# Altium schematic sheet Sheet1.SchDoc(Sheet1)
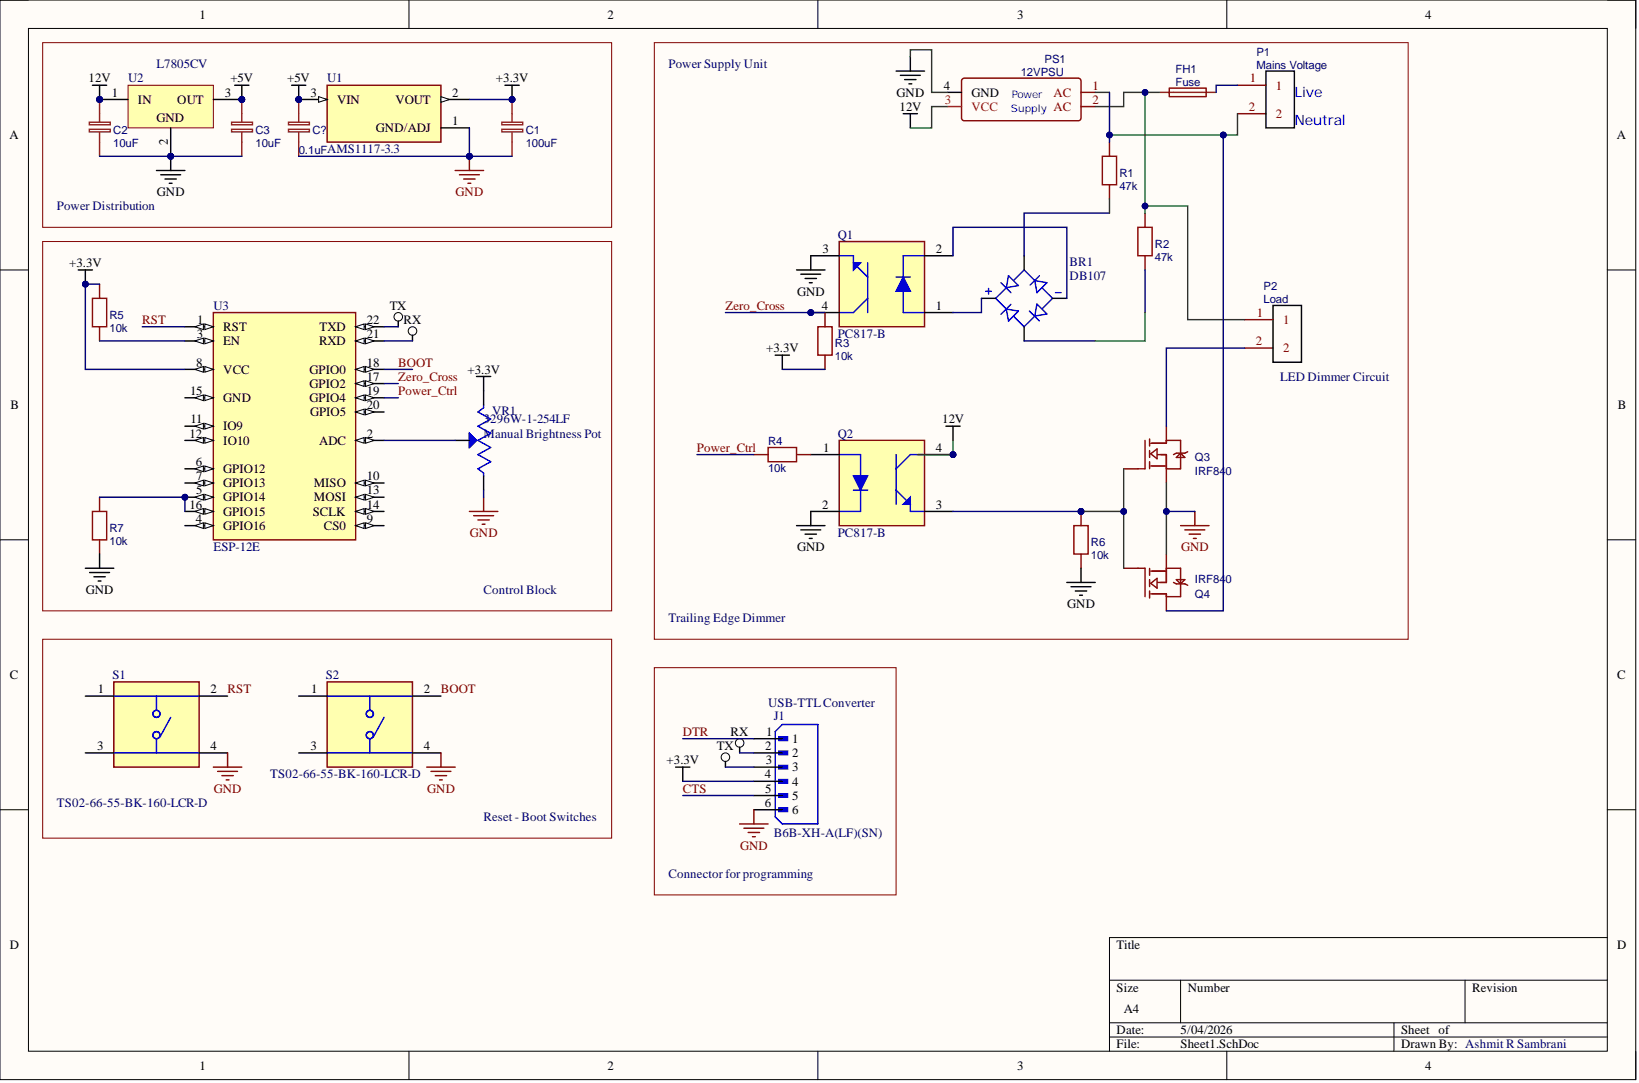
[diagram p0: Sheet1.SchDoc]

## Parts
BR1  DB107
C1  100uF
C2  10uF
C3  10uF
C?  0.1uF
FH1  Fuse
J1  B6B-XH-A(LF)(SN)
P1  Mains Voltage
P2  Load
PS1  12VPSU
Q1  PC817-B
Q2  PC817-B
Q3  IRF840
Q4  IRF840
R1  47k
R2  47k
R3  10k
R4  10k
R5  10k
R6  10k
R7  10k
S1  TS02-66-55-BK-160-LCR-D
S2  TS02-66-55-BK-160-LCR-D
U1  AMS1117-3.3
U2  L7805CV
U3  ESP-12E
VR1  3296W-1-254LF

## Nets
12V: C2.1 PS1.3(VCC) Q2.4 U2.1
+3.3V: C1.1 J1.4 R3.1 R5.2 U1.2 U3.8(VCC) VR1.3
+5V: C3.1 C?.1 U1.3 U2.3
GND: C1.2 C2.2 C3.2 C?.2 J1.6 PS1.4(GND) Q1.3 Q2.2 Q3.3 Q4.3 R6.1 R7.1 S1.4 S2.4 U1.1 U2.2 VR1.1
RX: J1.2 U3.21(RXD)
TX: J1.3 U3.22(TXD)
BOOT: S2.2 U3.18(GPIO0)
CTS: J1.5
DTR: J1.1
Power_Ctrl: R4.1 U3.19(GPIO4)
RST: S1.2 U3.1(RST)
Zero_Cross: Q1.4 R3.2 U3.17(GPIO2)
NetBR1_1: BR1.1 Q1.1
NetBR1_2: BR1.2 Q1.2
NetBR1_3: BR1.3 R1.1
NetBR1_4: BR1.4 R2.1
NetFH1_1: FH1.1 P2.1 PS1.2(AC) R2.2
NetFH1_2: FH1.2 P1.1
NetP1_2: P1.2 PS1.1(AC) Q4.4 R1.2
NetP2_2: P2.2 Q3.4
NetQ2_1: Q2.1 R4.2
NetQ2_3: Q2.3 Q3.1 Q4.1 R6.2
NetR5_1: R5.1 U3.3(EN)
NetR7_2: R7.2 U3.5(GPIO14) U3.16(GPIO15)
NetU3_2: U3.2(ADC) VR1.2

Not connected: S1 1 3; S2 1 3; U3 4(GPIO16) 6(GPIO12) 7(GPIO13) 9(CS0) 10(MISO) 11(IO9) 12(IO10) 13(MOSI) 14(SCLK) 15(GND) 20(GPIO5)

## Library data of the parts
BR1 = CMP-033-000001-1: Bridge Rectifier Single Phase Standard 1 kV Through Hole DB-1; MF: Micro Commercial Components; Check_prices: https://www.snapeda.com/parts/DB107/Micro+Commercial+Components/view-part/?ref=eda; Component Kind: Standard; Component Type: Standard; Design Item ID: DB107; MP: DB107; Package: EDIP-4 MCC; Pin Count: 4; SnapEDA_Link: https://www.snapeda.com/parts/DB107/Micro+Commercial+Components/view-part/?ref=snap; Source: DB107.SchLib; Library Name: Ashmita Das
C1-C3 C? = 2.2uF: nameAlias: Value(F); Component Kind: Standard; Manufacturer Part: ?; spicePre: C; spiceSymbolName: Cap_1206_EU
FH1 = Fuse: Manufacturer: Littelfuse; Manufacturer Part: 64600001003; Contributor: LCSC; Component Kind: Standard; spicePre: F; Supplier: LCSC; Supplier Part: C206887
J1 = CMP-398-000515-1: Connector Header Through Hole 6 position 0.098 (2.50mm); MF: JST Sales; Check_prices: https://www.snapeda.com/parts/B6B-XH-A(LF)(SN)/JST+Sales+America+Inc./view-part/?ref=eda; Component Kind: Standard; Component Type: Standard; Design Item ID: B6B-XH-A(LF)(SN); Library Name: CSD Robocon Workspace; MP: B6B-XH-A(LF)(SN); Package: None; Pin Count: 6; SnapEDA_Link: https://www.snapeda.com/parts/B6B-XH-A(LF)(SN)/JST+Sales+America+Inc./view-part/?ref=snap; Source: B6B-XH-A(LF)(SN).SchLib
P1 = Mains Voltage: Manufacturer Part: Screw terminal 2p; Contributor: georges_circuits; Component Kind: Standard; spicePre: P
P2 = Load: Manufacturer Part: Screw terminal 2p; Contributor: georges_circuits; Component Kind: Standard; spicePre: P
PS1 = 12VPSU: Manufacturer Part: Hi-Link/Tenstar; Contributor: doMOD; Component Kind: Standard; spicePre: P
Q1 Q2 = CMP-035-000000-1: Phototransistors 4-DIP (0.300, 7.62mm); MF: American Bright; Check_prices: https://www.snapeda.com/parts/PC817-B/American+Bright/view-part/?ref=eda; Component Kind: Standard; Component Type: Standard; Design Item ID: PC817-B; MP: PC817-B; Package: DIP-4 American Bright; Pin Count: 4; SnapEDA_Link: https://www.snapeda.com/parts/PC817-B/American+Bright/view-part/?ref=snap; Source: PC817-B.SchLib; Library Name: Ashmita Das
Q3 Q4 = IRF840: Manufacturer: VISHAY; Manufacturer Part: IRF840STRPBF; Contributor: LCSC; SMT Type: Extend; Component Kind: Standard; LCSC Assembly: Yes; spicePre: Q; Supplier: LCSC; Supplier Part: C2646
R1-R5 R7 = 47k: nameAlias: Value(Ω); Component Kind: Standard; Manufacturer Part: ?; spicePre: R; spiceSymbolName: Res_1206(1/4W)_EU
R6 = 10k: nameAlias: Value(Ω); Component Kind: Standard; Manufacturer Part: ?; spicePre: R; spiceSymbolName: Res_1206(1/4W)_EU
S1 S2 = CMP-342-000000-2: 5mm Tactilte Push Button; Availability: Unavailable; Component Kind: Standard; Component Type: Standard; Design Item ID: TS02-66-55-BK-160-LCR-D; Library Name: CSD Robocon Workspace; MP: TS02-66-55-BK-160-LCR-D; Package: None; Pin Count: 4; Price: None; Source: TS02-66-55-BK-160-LCR-D.SchLib
U1 = CMP-014-00002-1: Sot223/Pkg 1-Amp 3.3-Volt Low Drop Out Voltage Regulatator; MF: Advanced Monolithic Systems; Case/Package: SOT-223 Seeed Technology; Check_prices: https://www.snapeda.com/parts/AMS1117-3.3/Advanced+Monolithic+Systems/view-part/?ref=eda; Component Kind: Standard; Component Type: Standard; Design Item ID: AMS1117-3.3; MP: AMS1117-3.3; Pins: 3; SnapEDA_Link: https://www.snapeda.com/parts/AMS1117-3.3/Advanced+Monolithic+Systems/view-part/?ref=snap; Source: AMS1117-3.3.SchLib; Library Name: Ashmita Das
U2 = CMP-019-00018-3: Positive Voltage Regulator IC, 1.5 A, 5 V, 0 to 125 degC, 3-Pin TO-220, RoHS, Tube; Manufacturer: STMicroelectronics; Dropout Voltage: 2V; Max Input Voltage: 35V; Max Ouput Current: 1.5A; Max Supply Voltage: 20V; Min Input Voltage: 7V; Min Supply Voltage: 8V; Nominal Output Voltage: 5V; Outputs: 1; Case/Package: TO-220; Component Kind: Standard; Datasheet URL: https://datasheet.ciiva.com/15111/getdatasheetpartid-44136-15111027.pdf; Datasheet Version: Rev 30, 09/2012; Manufacturer URL: http://www.st.com/; Max Operating Temperature: 125°C; Min Operating Temperature: 0°C; Mounting Technology: TH; Output Current: 1.5A; Output Voltage: 5V; Package Quantity: 50; Packaging: Rail/Tube; Pins: 3; RoHS Compliant: True; Library Name: Ashmita Das
U3 = CMP-037-000000-1: WiFi Module; MF: AI-Thinker; MP: ESP-12F; Check_prices: https://www.snapeda.com/parts/ESP-12F/AI-Thinker/view-part/?ref=eda; Component Kind: Standard; Component Type: Standard; Design Item ID: ESP-12F; Package: Package; Pin Count: 22; SnapEDA_Link: https://www.snapeda.com/parts/ESP-12F/AI-Thinker/view-part/?ref=snap; Source: ESP-12F.SchLib; Library Name: Ashmita Das
VR1 = CMP-402-000001-1: 250 kOhms 0.5W, 1/2W PC Pins Through Hole Trimmer Potentiometer Cermet 25.0 Turn Top Adjustment; MF: Bourns; Availability: In Stock; Check_prices: https://www.snapeda.com/parts/3296W-1-254LF/Bourns+-+J.W.+Miller/view-part/?ref=eda; Component Kind: Standard; Component Type: Standard; Design Item ID: 3296W-1-254LF; Library Name: CSD Robocon Workspace; MP: 3296W-1-254LF; Package: SOT-23-5 Bourns; Pin Count: 3; Price: None; Purchase-URL: https://pricing.snapeda.com/search/part/3296W-1-254LF/?ref=eda; SnapEDA_Link: https://www.snapeda.com/parts/3296W-1-254LF/Bourns+-+J.W.+Miller/view-part/?ref=snap; Source: 3296W-1-254LF.SchLib
